# Altium schematic sheet show.SchDoc(show)
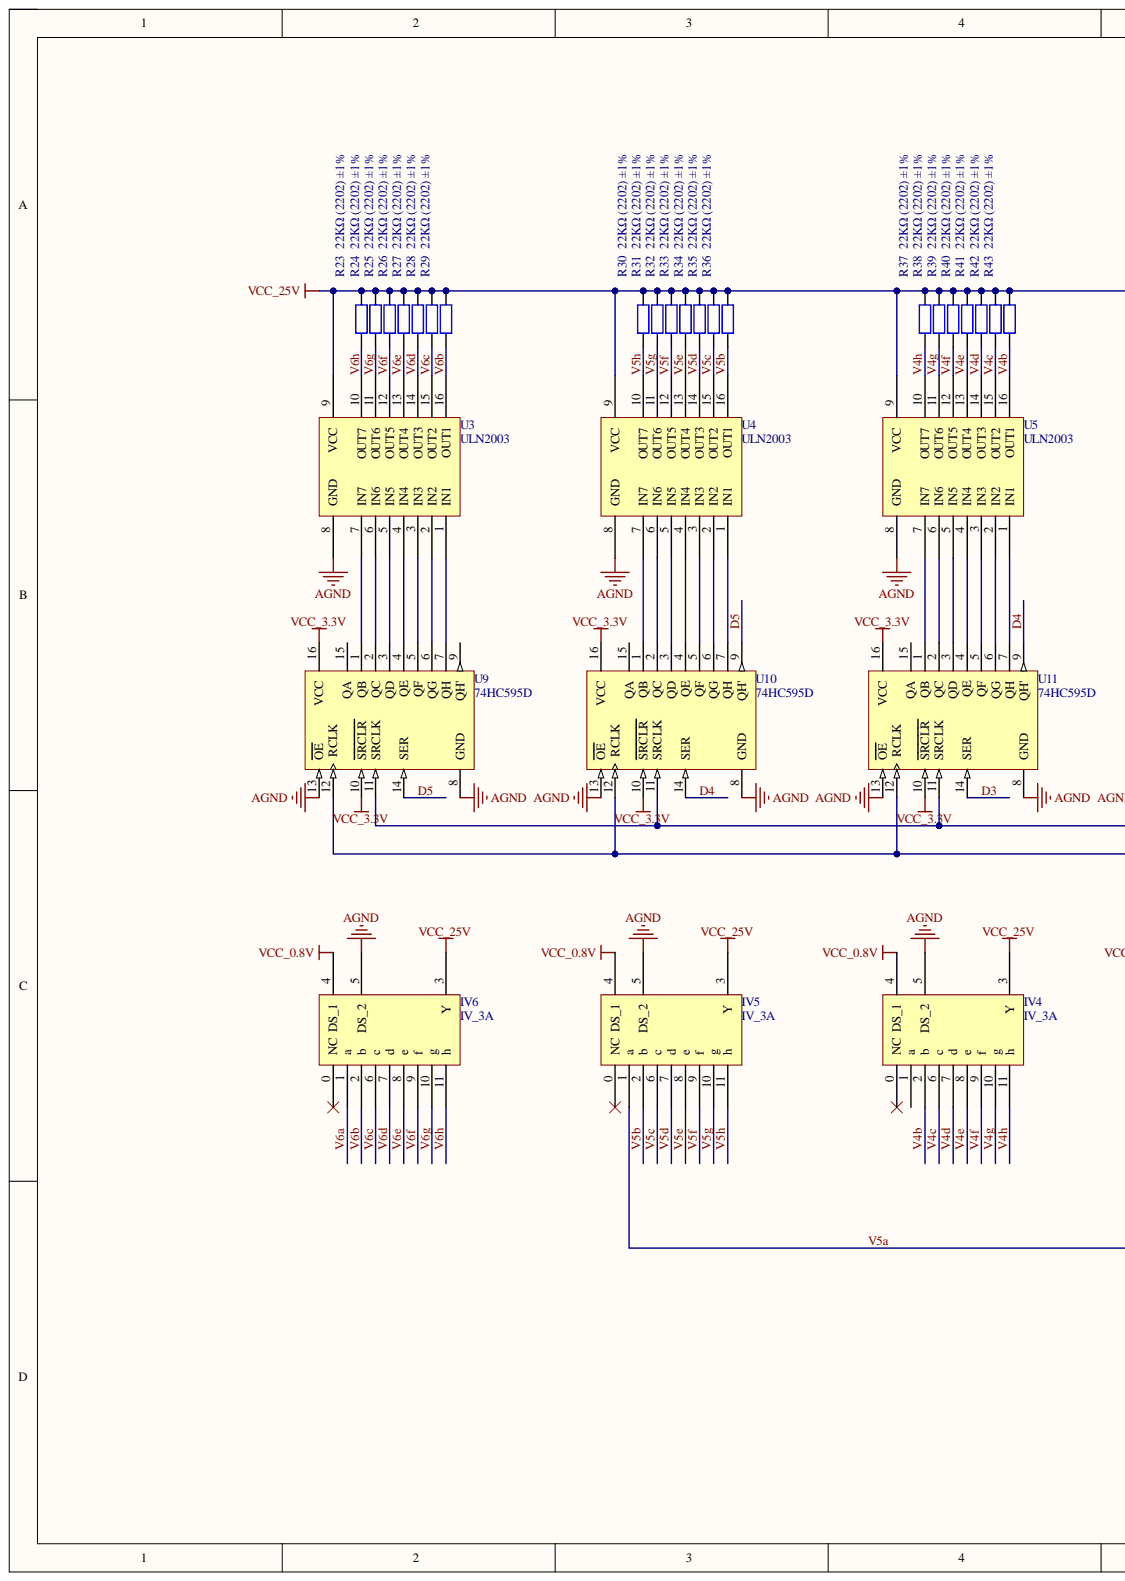
[diagram p0: show.SchDoc, left]
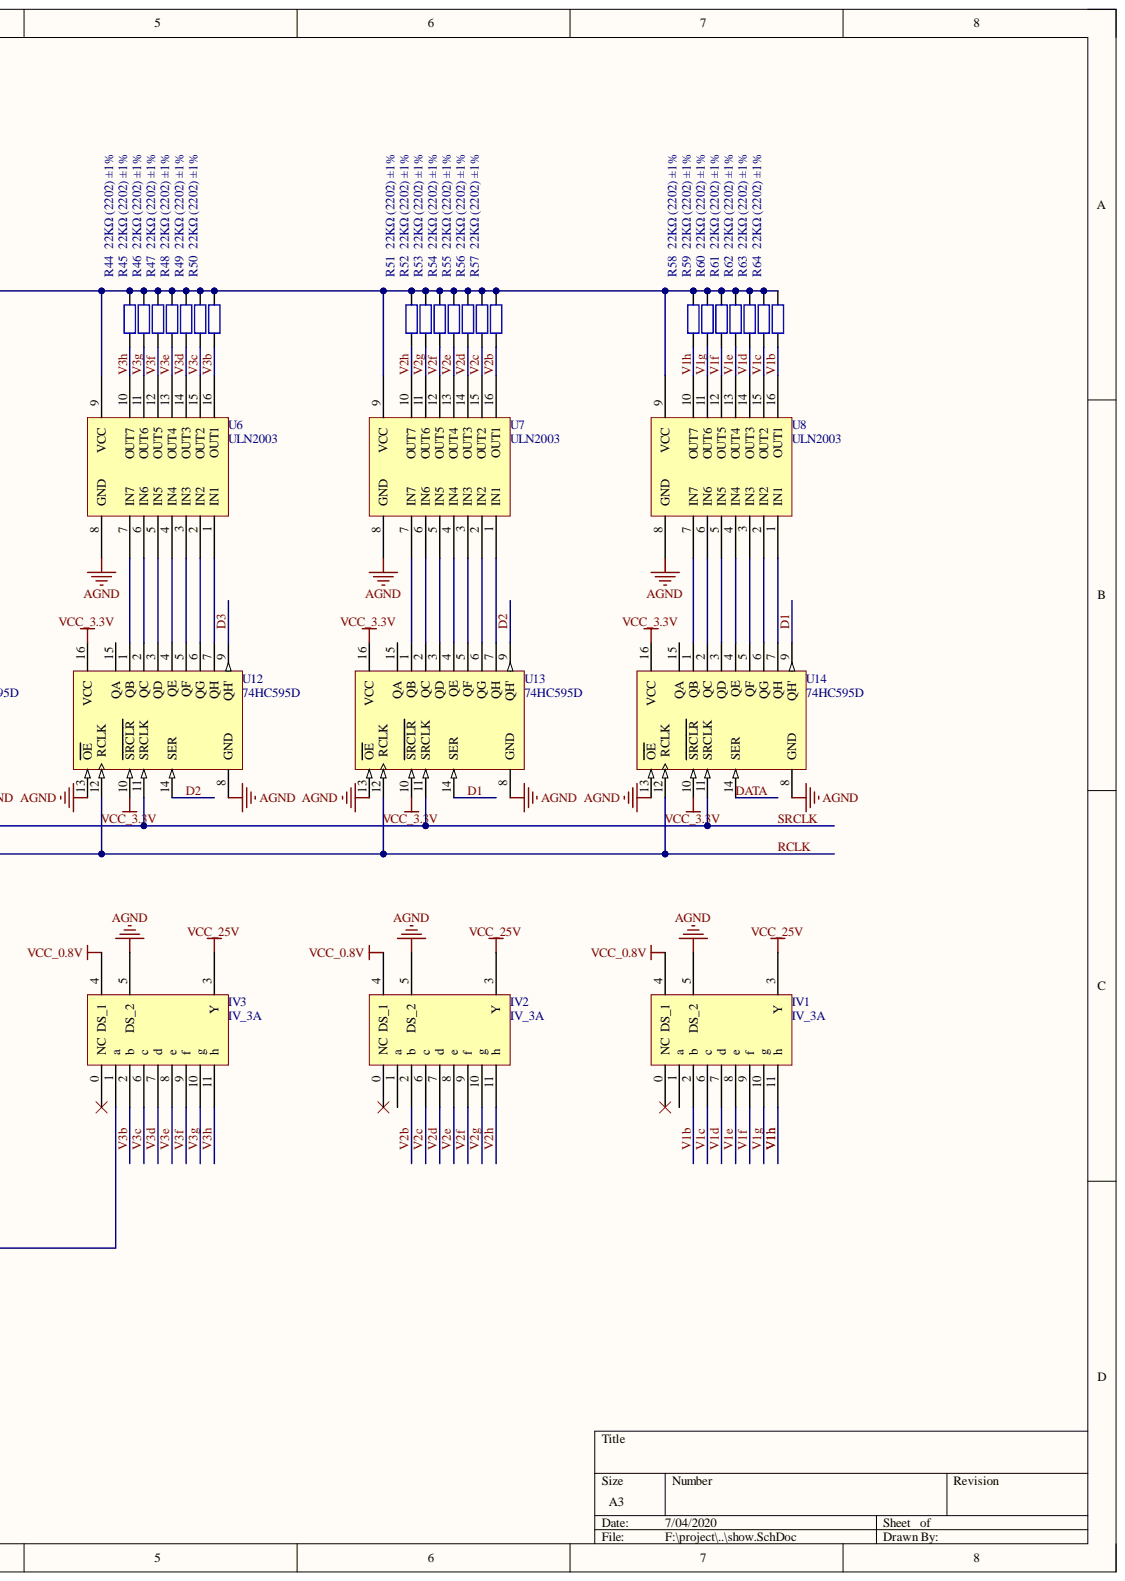
[diagram p1: show.SchDoc, right]

## Parts
IV1  IV_3A  [IV_3A]
IV2  IV_3A  [IV_3A]
IV3  IV_3A  [IV_3A]
IV4  IV_3A  [IV_3A]
IV5  IV_3A  [IV_3A]
IV6  IV_3A  [IV_3A]
R23  22KΩ (2202) ±1%  [0603_R]
R24  22KΩ (2202) ±1%  [0603_R]
R25  22KΩ (2202) ±1%  [0603_R]
R26  22KΩ (2202) ±1%  [0603_R]
R27  22KΩ (2202) ±1%  [0603_R]
R28  22KΩ (2202) ±1%  [0603_R]
R29  22KΩ (2202) ±1%  [0603_R]
R30  22KΩ (2202) ±1%  [0603_R]
R31  22KΩ (2202) ±1%  [0603_R]
R32  22KΩ (2202) ±1%  [0603_R]
R33  22KΩ (2202) ±1%  [0603_R]
R34  22KΩ (2202) ±1%  [0603_R]
R35  22KΩ (2202) ±1%  [0603_R]
R36  22KΩ (2202) ±1%  [0603_R]
R37  22KΩ (2202) ±1%  [0603_R]
R38  22KΩ (2202) ±1%  [0603_R]
R39  22KΩ (2202) ±1%  [0603_R]
R40  22KΩ (2202) ±1%  [0603_R]
R41  22KΩ (2202) ±1%  [0603_R]
R42  22KΩ (2202) ±1%  [0603_R]
R43  22KΩ (2202) ±1%  [0603_R]
R44  22KΩ (2202) ±1%  [0603_R]
R45  22KΩ (2202) ±1%  [0603_R]
R46  22KΩ (2202) ±1%  [0603_R]
R47  22KΩ (2202) ±1%  [0603_R]
R48  22KΩ (2202) ±1%  [0603_R]
R49  22KΩ (2202) ±1%  [0603_R]
R50  22KΩ (2202) ±1%  [0603_R]
R51  22KΩ (2202) ±1%  [0603_R]
R52  22KΩ (2202) ±1%  [0603_R]
R53  22KΩ (2202) ±1%  [0603_R]
R54  22KΩ (2202) ±1%  [0603_R]
R55  22KΩ (2202) ±1%  [0603_R]
R56  22KΩ (2202) ±1%  [0603_R]
R57  22KΩ (2202) ±1%  [0603_R]
R58  22KΩ (2202) ±1%  [0603_R]
R59  22KΩ (2202) ±1%  [0603_R]
R60  22KΩ (2202) ±1%  [0603_R]
R61  22KΩ (2202) ±1%  [0603_R]
R62  22KΩ (2202) ±1%  [0603_R]
R63  22KΩ (2202) ±1%  [0603_R]
R64  22KΩ (2202) ±1%  [0603_R]
U3  ULN2003  [SOIC-16_150mil]
U4  ULN2003  [SOIC-16_150mil]
U5  ULN2003  [SOIC-16_150mil]
U6  ULN2003  [SOIC-16_150mil]
U7  ULN2003  [SOIC-16_150mil]
U8  ULN2003  [SOIC-16_150mil]
U9  74HC595D  [SOIC-16_150mil]
U10  74HC595D  [SOIC-16_150mil]
U11  74HC595D  [SOIC-16_150mil]
U12  74HC595D  [SOIC-16_150mil]
U13  74HC595D  [SOIC-16_150mil]
U14  74HC595D  [SOIC-16_150mil]

## Nets
AGND: IV1.5(DS_2) IV2.5(DS_2) IV3.5(DS_2) IV4.5(DS_2) IV5.5(DS_2) IV6.5(DS_2) U3.8(GND) U4.8(GND) U5.8(GND) U6.8(GND) U7.8(GND) U8.8(GND) U9.8(GND) U9.13(OE) U10.8(GND) U10.13(OE) U11.8(GND) U11.13(OE) U12.8(GND) U12.13(OE) U13.8(GND) U13.13(OE) U14.8(GND) U14.13(OE)
VCC_0.8V: IV1.4(DS_1) IV2.4(DS_1) IV3.4(DS_1) IV4.4(DS_1) IV5.4(DS_1) IV6.4(DS_1)
VCC_3.3V: U9.10(SRCLR) U9.16(VCC) U10.10(SRCLR) U10.16(VCC) U11.10(SRCLR) U11.16(VCC) U12.10(SRCLR) U12.16(VCC) U13.10(SRCLR) U13.16(VCC) U14.10(SRCLR) U14.16(VCC)
VCC_25V: IV1.3(Y) IV2.3(Y) IV3.3(Y) IV4.3(Y) IV5.3(Y) IV6.3(Y) R23.2 R24.2 R25.2 R26.2 R27.2 R28.2 R29.2 R30.2 R31.2 R32.2 R33.2 R34.2 R35.2 R36.2 R37.2 R38.2 R39.2 R40.2 R41.2 R42.2 R43.2 R44.2 R45.2 R46.2 R47.2 R48.2 R49.2 R50.2 R51.2 R52.2 R53.2 R54.2 R55.2 R56.2 R57.2 R58.2 R59.2 R60.2 R61.2 R62.2 R63.2 R64.2 U3.9(VCC) U4.9(VCC) U5.9(VCC) U6.9(VCC) U7.9(VCC) U8.9(VCC)
D1: U13.14(SER) U14.9(QH')
D2: U12.14(SER) U13.9(QH')
D3: U11.14(SER) U12.9(QH')
D4: U10.14(SER) U11.9(QH')
D5: U9.14(SER) U10.9(QH')
DATA: U14.14(SER)
RCLK: U9.12(RCLK) U10.12(RCLK) U11.12(RCLK) U12.12(RCLK) U13.12(RCLK) U14.12(RCLK)
SRCLK: U9.11(SRCLK) U10.11(SRCLK) U11.11(SRCLK) U12.11(SRCLK) U13.11(SRCLK) U14.11(SRCLK)
V1b: IV1.2(b) R64.1 U8.16(OUT1)
V1c: IV1.6(c) R63.1 U8.15(OUT2)
V1d: IV1.7(d) R62.1 U8.14(OUT3)
V1e: IV1.8(e) R61.1 U8.13(OUT4)
V1f: IV1.9(f) R60.1 U8.12(OUT5)
V1g: IV1.10(g) R59.1 U8.11(OUT6)
V1h: IV1.11(h) R58.1 U8.10(OUT7)
V2b: IV2.2(b) R57.1 U7.16(OUT1)
V2c: IV2.6(c) R56.1 U7.15(OUT2)
V2d: IV2.7(d) R55.1 U7.14(OUT3)
V2e: IV2.8(e) R54.1 U7.13(OUT4)
V2f: IV2.9(f) R53.1 U7.12(OUT5)
V2g: IV2.10(g) R52.1 U7.11(OUT6)
V2h: IV2.11(h) R51.1 U7.10(OUT7)
V3b: IV3.2(b) R50.1 U6.16(OUT1)
V3c: IV3.6(c) R49.1 U6.15(OUT2)
V3d: IV3.7(d) R48.1 U6.14(OUT3)
V3e: IV3.8(e) R47.1 U6.13(OUT4)
V3f: IV3.9(f) R46.1 U6.12(OUT5)
V3g: IV3.10(g) R45.1 U6.11(OUT6)
V3h: IV3.11(h) R44.1 U6.10(OUT7)
V4b: IV4.2(b) R43.1 U5.16(OUT1)
V4c: IV4.6(c) R42.1 U5.15(OUT2)
V4d: IV4.7(d) R41.1 U5.14(OUT3)
V4e: IV4.8(e) R40.1 U5.13(OUT4)
V4f: IV4.9(f) R39.1 U5.12(OUT5)
V4g: IV4.10(g) R38.1 U5.11(OUT6)
V4h: IV4.11(h) R37.1 U5.10(OUT7)
V5a: IV3.1(a) IV5.1(a)
V5b: IV5.2(b) R36.1 U4.16(OUT1)
V5c: IV5.6(c) R35.1 U4.15(OUT2)
V5d: IV5.7(d) R34.1 U4.14(OUT3)
V5e: IV5.8(e) R33.1 U4.13(OUT4)
V5f: IV5.9(f) R32.1 U4.12(OUT5)
V5g: IV5.10(g) R31.1 U4.11(OUT6)
V5h: IV5.11(h) R30.1 U4.10(OUT7)
V6a: IV6.1(a)
V6b: IV6.2(b) R29.1 U3.16(OUT1)
V6c: IV6.6(c) R28.1 U3.15(OUT2)
V6d: IV6.7(d) R27.1 U3.14(OUT3)
V6e: IV6.8(e) R26.1 U3.13(OUT4)
V6f: IV6.9(f) R25.1 U3.12(OUT5)
V6g: IV6.10(g) R24.1 U3.11(OUT6)
V6h: IV6.11(h) R23.1 U3.10(OUT7)
NetU3_1: U3.1(IN1) U9.7(QH)
NetU3_2: U3.2(IN2) U9.6(QG)
NetU3_3: U3.3(IN3) U9.5(QF)
NetU3_4: U3.4(IN4) U9.4(QE)
NetU3_5: U3.5(IN5) U9.3(QD)
NetU3_6: U3.6(IN6) U9.2(QC)
NetU3_7: U3.7(IN7) U9.1(QB)
NetU4_1: U4.1(IN1) U10.7(QH)
NetU4_2: U4.2(IN2) U10.6(QG)
NetU4_3: U4.3(IN3) U10.5(QF)
NetU4_4: U4.4(IN4) U10.4(QE)
NetU4_5: U4.5(IN5) U10.3(QD)
NetU4_6: U4.6(IN6) U10.2(QC)
NetU4_7: U4.7(IN7) U10.1(QB)
NetU5_1: U5.1(IN1) U11.7(QH)
NetU5_2: U5.2(IN2) U11.6(QG)
NetU5_3: U5.3(IN3) U11.5(QF)
NetU5_4: U5.4(IN4) U11.4(QE)
NetU5_5: U5.5(IN5) U11.3(QD)
NetU5_6: U5.6(IN6) U11.2(QC)
NetU5_7: U5.7(IN7) U11.1(QB)
NetU6_1: U6.1(IN1) U12.7(QH)
NetU6_2: U6.2(IN2) U12.6(QG)
NetU6_3: U6.3(IN3) U12.5(QF)
NetU6_4: U6.4(IN4) U12.4(QE)
NetU6_5: U6.5(IN5) U12.3(QD)
NetU6_6: U6.6(IN6) U12.2(QC)
NetU6_7: U6.7(IN7) U12.1(QB)
NetU7_1: U7.1(IN1) U13.7(QH)
NetU7_2: U7.2(IN2) U13.6(QG)
NetU7_3: U7.3(IN3) U13.5(QF)
NetU7_4: U7.4(IN4) U13.4(QE)
NetU7_5: U7.5(IN5) U13.3(QD)
NetU7_6: U7.6(IN6) U13.2(QC)
NetU7_7: U7.7(IN7) U13.1(QB)
NetU8_1: U8.1(IN1) U14.7(QH)
NetU8_2: U8.2(IN2) U14.6(QG)
NetU8_3: U8.3(IN3) U14.5(QF)
NetU8_4: U8.4(IN4) U14.4(QE)
NetU8_5: U8.5(IN5) U14.3(QD)
NetU8_6: U8.6(IN6) U14.2(QC)
NetU8_7: U8.7(IN7) U14.1(QB)

Not connected: IV1 0(NC) 1(a); IV2 0(NC) 1(a); IV3 0(NC); IV4 0(NC) 1(a); IV5 0(NC); IV6 0(NC); U9 9(QH') 15(QA); U10 15(QA); U11 15(QA); U12 15(QA); U13 15(QA); U14 15(QA)

## Library data of the parts
R23-R64 = 0603 22KΩ (2202) 1%: 贴片电阻; Component Kind: Standard; ComponentLink1Description: 供应商链接; ComponentLink1URL: http://www.szlcsc.com/product/details_32812.html; Library Name: Miscellaneous Devices LC.IntLib; Package: 0603; Supplier: LC; SuppliersPartNumber: C31850
U9-U14 = 74HC595D_[C5947]: 74系列逻辑芯片; Component Kind: Standard; ComponentLink1Description: 供应商链接; ComponentLink1URL: http://www.szlcsc.com/product/details_6413.html; Library Name: Miscellaneous Devices LC.IntLib; Package: SOIC-16_150mil; Supplier: LC; SuppliersPartNumber: C5947
IV1-IV6 = IV_3A: Component Kind: Standard; Library Name: IV-3a.IntLib
U3-U8 = ULN2003: Component Kind: Standard; Library Name: IV-3a.IntLib
